# Altium schematic sheet EC20_TBOX.SchDoc(EC20_TBOX)
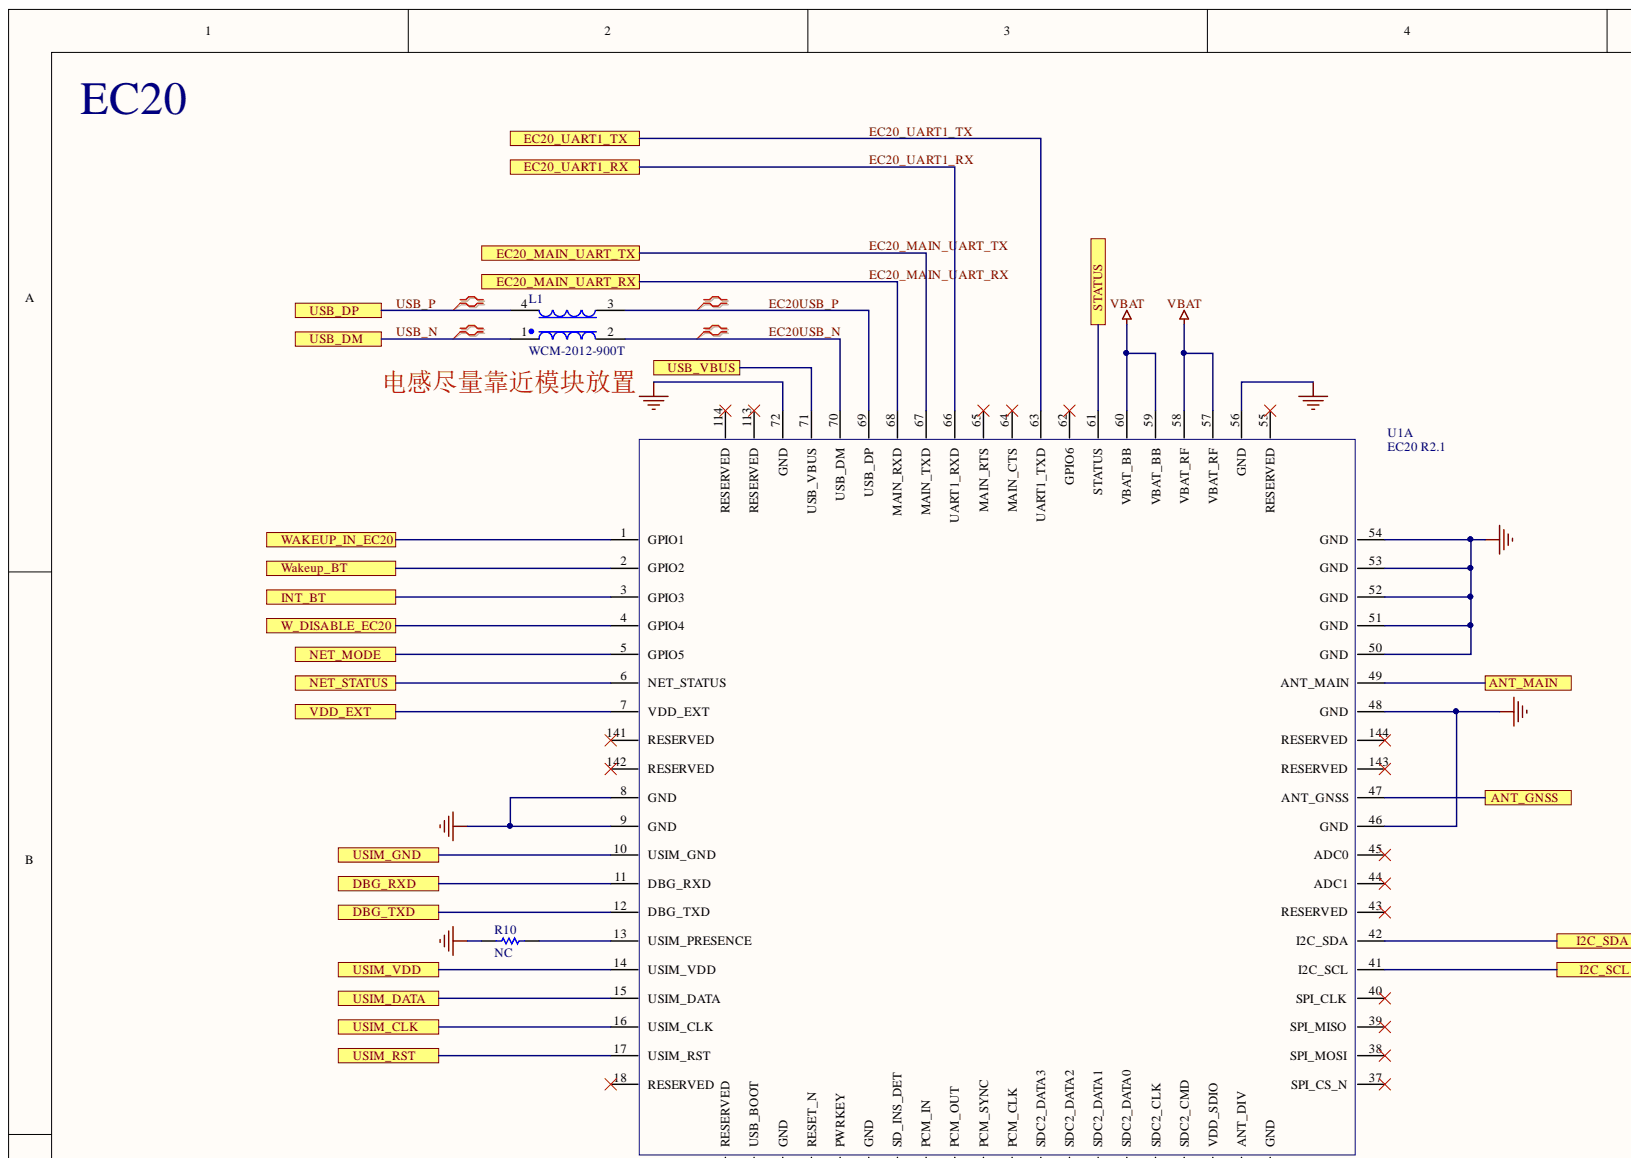
[diagram p0: EC20_TBOX.SchDoc, top left]
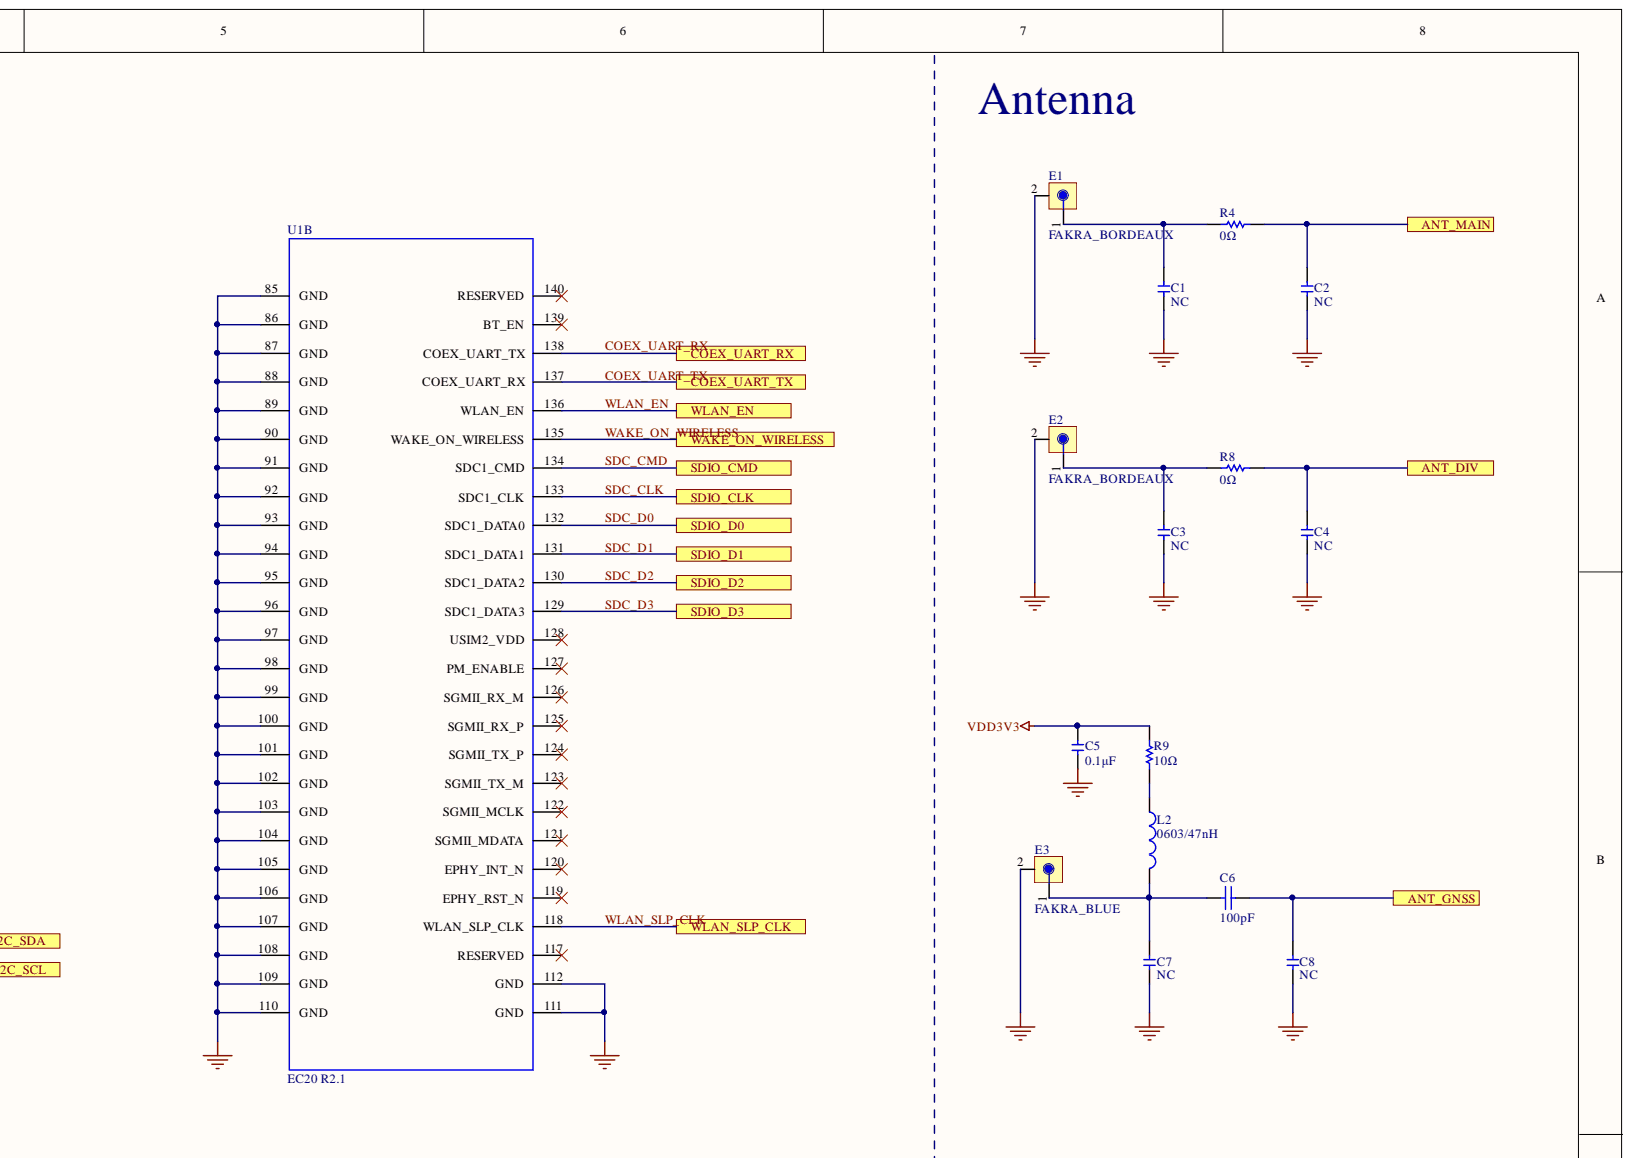
[diagram p1: EC20_TBOX.SchDoc, top right]
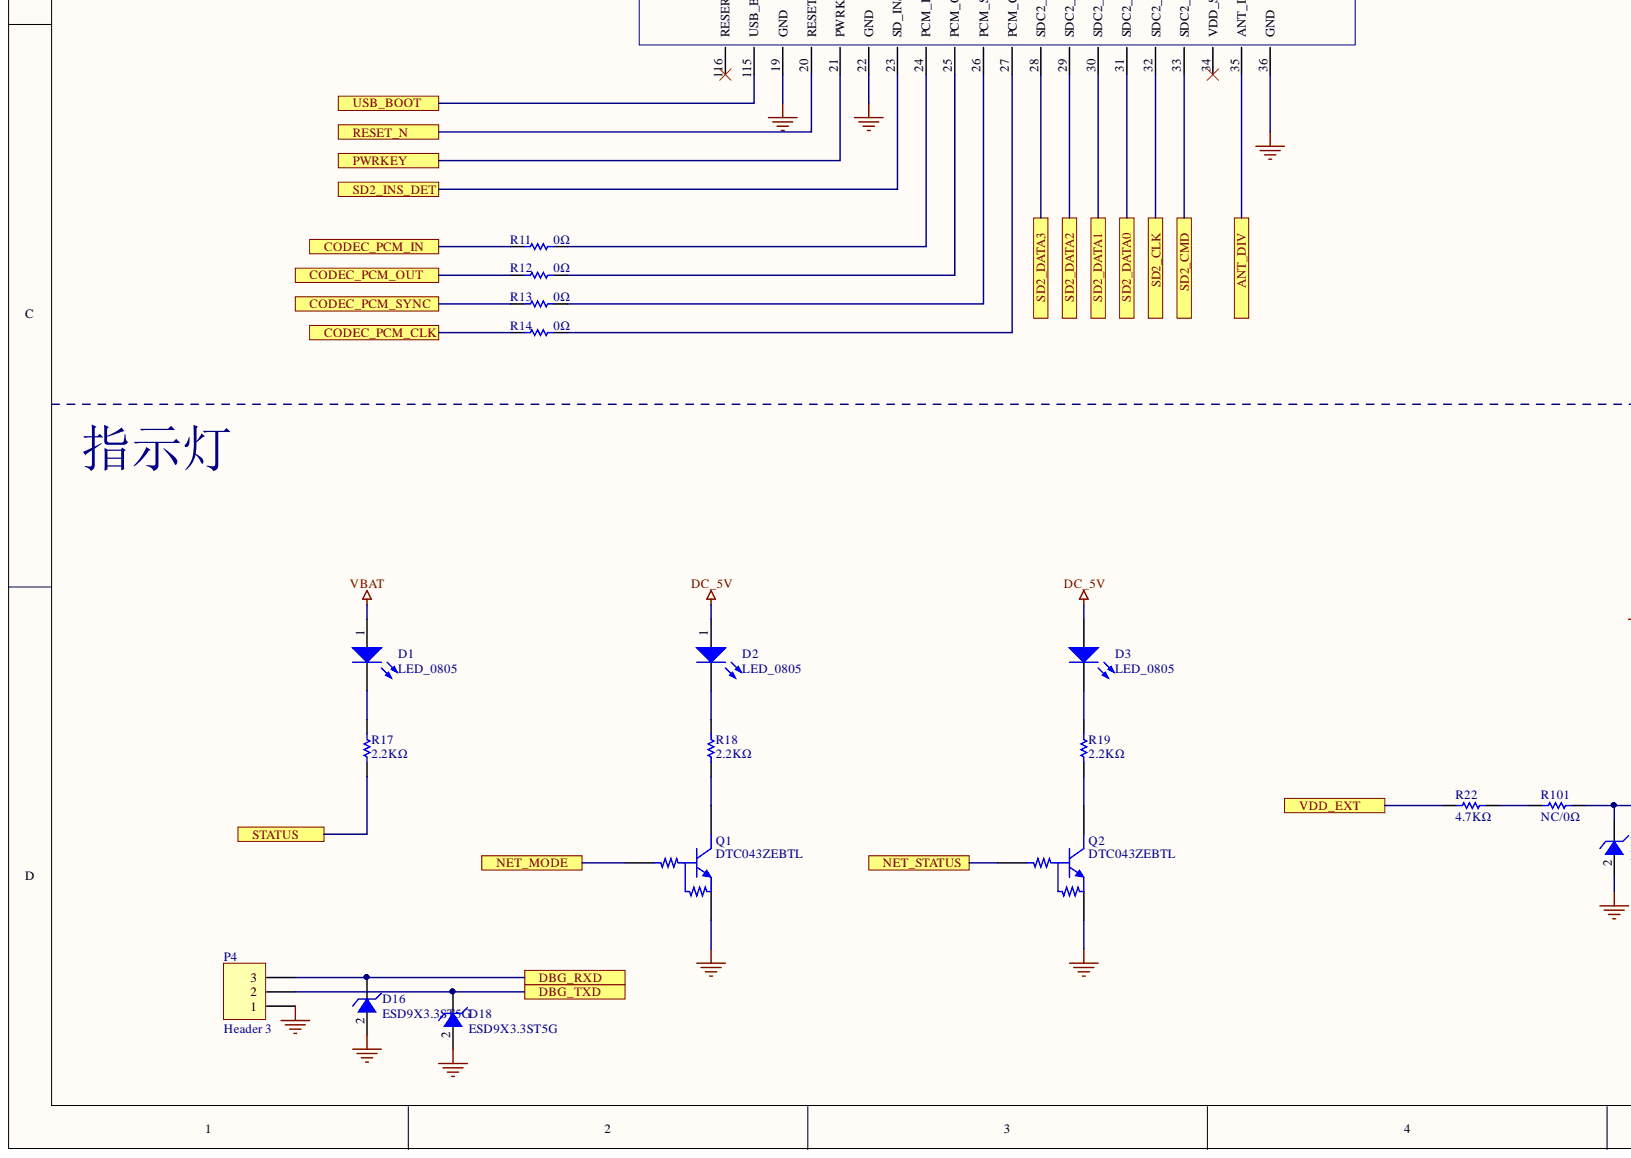
[diagram p2: EC20_TBOX.SchDoc, bottom left]
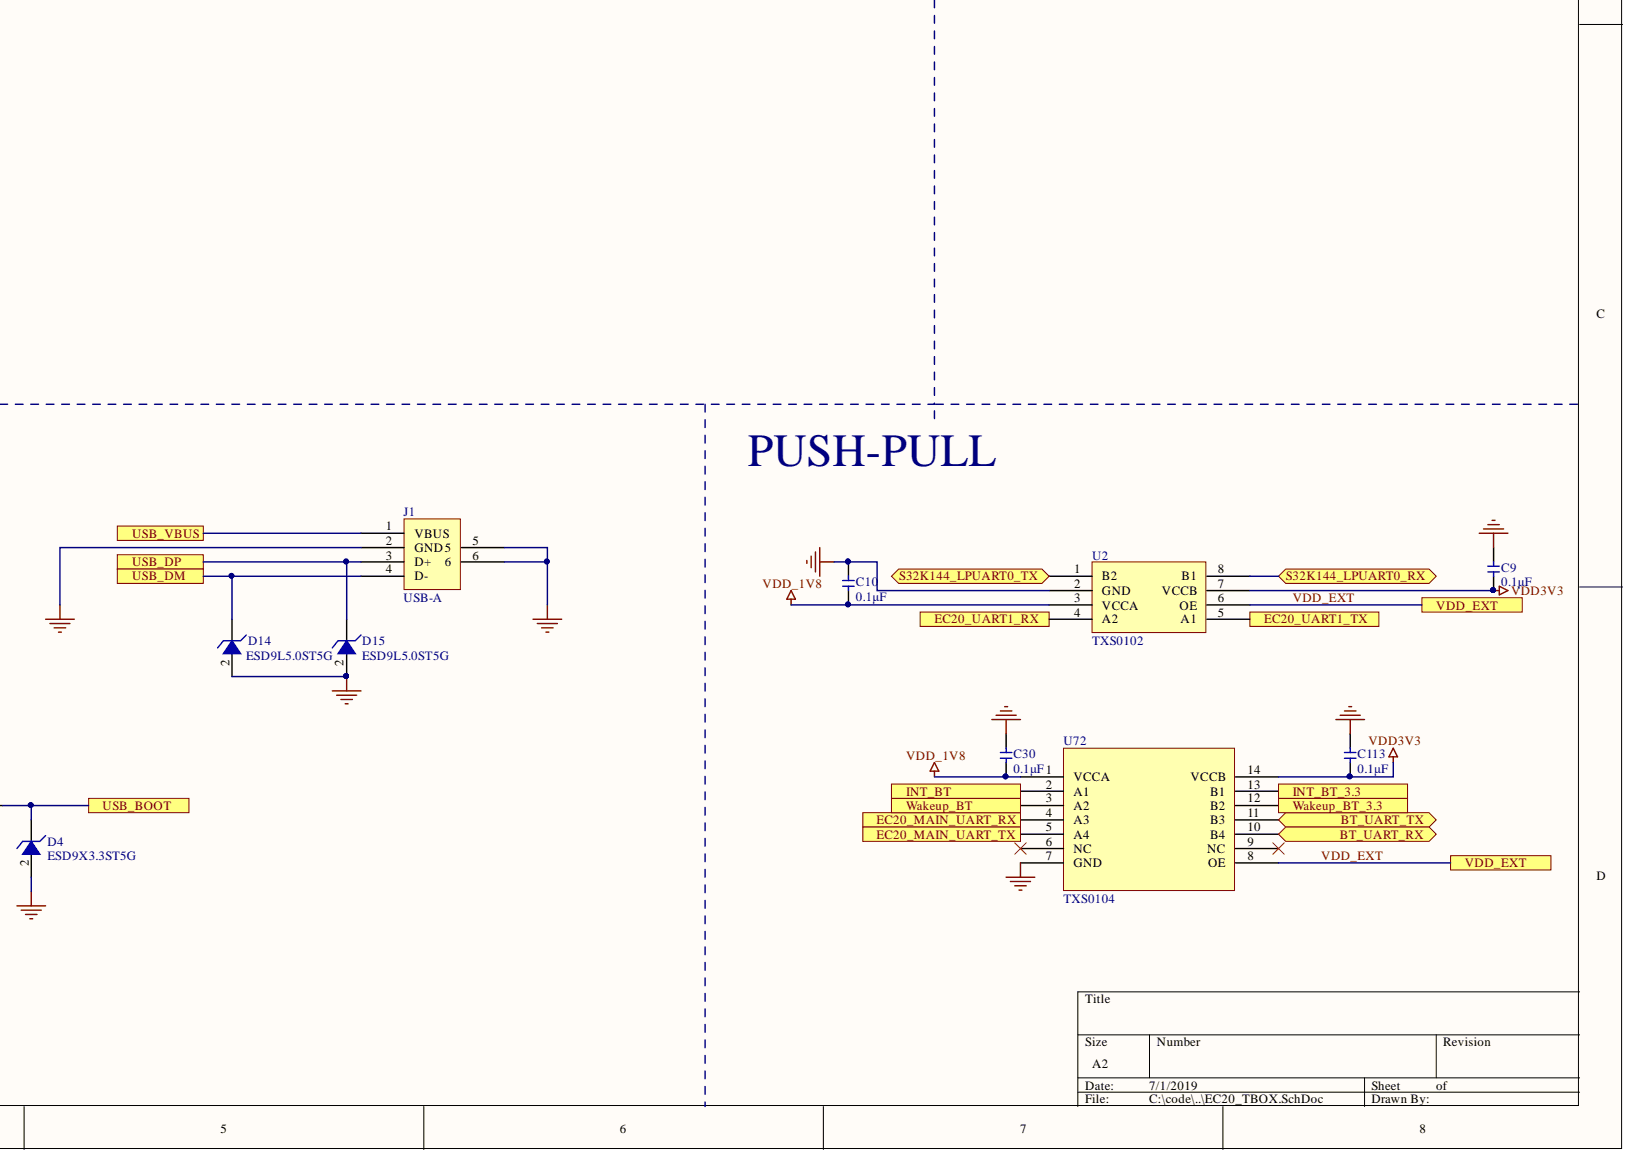
[diagram p3: EC20_TBOX.SchDoc, bottom right]

## Parts
C1  NC  [C0402]
C2  NC  [C0402]
C3  NC  [C0402]
C4  NC  [C0402]
C5  0.1μF  [C0402]
C6  100pF  [C0402]
C7  NC  [C0402]
C8  NC  [C0402]
C9  0.1μF  [C0402]
C10  0.1μF  [C0402]
C30  0.1μF  [C0402]
C113  0.1μF  [C0402]
D1  LED_0805  [LED_0805]
D2  LED_0805  [LED_0805]
D3  LED_0805  [LED_0805]
D4  ESD9X3.3ST5G  [SOD-923]
D14  ESD9L5.0ST5G  [SOD-923]
D15  ESD9L5.0ST5G  [SOD-923]
D16  ESD9X3.3ST5G  [SOD-923]
D18  ESD9X3.3ST5G  [SOD-923]
E1  FAKRA_BORDEAUX  [SMB-BORDEAUX_LTE]
E2  FAKRA_BORDEAUX  [SMB-BORDEAUX_LTE]
E3  FAKRA_BLUE  [SMB-BLUE_GPS]
J1  USB-A  [GT17HN-4DP-2H(A)(30)]
L1  WCM-2012-900T  [WCM-2012-900T]
L2  0603/47nH  [L0603]
P4  Header 3  [HDR3X1_A]
Q1  DTC043ZEBTL  [SOT723]
Q2  DTC043ZEBTL  [SOT723]
R4  0Ω  [R0402]
R8  0Ω  [R0402]
R9  10Ω  [R0402]
R10  NC  [R0402]
R11  0Ω  [R0402]
R12  0Ω  [R0402]
R13  0Ω  [R0402]
R14  0Ω  [R0402]
R17  2.2KΩ  [R0402]
R18  2.2KΩ  [R0402]
R19  2.2KΩ  [R0402]
R22  4.7KΩ  [R0402]
R101  NC/0Ω  [R0402]
U1  EC20 R2.1  [EC20_R2.1]  (parts A,B)
U2  TXS0102  [DCU-8]
U72  TXS0104  [TSSOP14]

## Ports
ANT_DIV, ANT_GNSS, ANT_MAIN, BT_UART_RX, BT_UART_TX, CODEC_PCM_CLK, CODEC_PCM_IN, CODEC_PCM_OUT, CODEC_PCM_SYNC, COEX_UART_RX, COEX_UART_TX, DBG_RXD, DBG_TXD, EC20_MAIN_UART_RX, EC20_MAIN_UART_TX, EC20_UART1_RX, EC20_UART1_TX, I2C_SCL, I2C_SDA, INT_BT, INT_BT_3.3, NET_MODE, NET_STATUS, PWRKEY, RESET_N, S32K144_LPUART0_RX, S32K144_LPUART0_TX, SD2_CLK, SD2_CMD, SD2_DATA0, SD2_DATA1, SD2_DATA2, SD2_DATA3, SD2_INS_DET, SDIO_CLK, SDIO_CMD, SDIO_D0, SDIO_D1, SDIO_D2, SDIO_D3, STATUS, USB_BOOT, USB_DM, USB_DP, USB_VBUS, USIM_CLK, USIM_DATA, USIM_GND, USIM_RST, USIM_VDD, VDD_EXT, W_DISABLE_EC20, WAKE_ON_WIRELESS, Wakeup_BT, Wakeup_BT_3.3, WAKEUP_IN_EC20, WLAN_EN, WLAN_SLP_CLK

## Nets
DC_5V: D2.1 D3.1
GND: C1.2 C2.2 C3.2 C4.2 C5.2 C7.2 C8.2 C9.2 C10.1 C30.1 C113.2 D4.2 D14.2 D15.2 D16.2 D18.2 E1.2 E2.2 E3.2 J1.2(GND) J1.5 J1.6 P4.1 Q1.2 Q2.2 R10.1 U1.8(GND) U1.9(GND) U1.19(GND) U1.22(GND) U1.36(GND) U1.46(GND) U1.48(GND) U1.50(GND) U1.51(GND) U1.52(GND) U1.53(GND) U1.54(GND) U1.56(GND) U1.72(GND) U1.85(GND) U1.86(GND) U1.87(GND) U1.88(GND) U1.89(GND) U1.90(GND) U1.91(GND) U1.92(GND) U1.93(GND) U1.94(GND) U1.95(GND) U1.96(GND) U1.97(GND) U1.98(GND) U1.99(GND) U1.100(GND) U1.101(GND) U1.102(GND) U1.103(GND) U1.104(GND) U1.105(GND) U1.106(GND) U1.107(GND) U1.108(GND) U1.109(GND) U1.110(GND) U1.111(GND) U1.112(GND) U2.2(GND) U72.7(GND)
VBAT: D1.1 U1.57(VBAT_RF) U1.58(VBAT_RF) U1.59(VBAT_BB) U1.60(VBAT_BB)
VDD3V3: C5.1 C9.1 C113.1 R9.1 U2.7(VCCB) U72.14(VCCB)
VDD_1V8: C10.2 C30.2 U2.3(VCCA) U72.1(VCCA)
ANT_DIV: C4.1 R8.1 U1.35(ANT_DIV) ; port ANT_DIV
ANT_GNSS: C6.1 C8.1 U1.47(ANT_GNSS) ; port ANT_GNSS
ANT_MAIN: C2.1 R4.1 U1.49(ANT_MAIN) ; port ANT_MAIN
BT_UART_RX: U72.10(B4) ; port BT_UART_RX
BT_UART_TX: U72.11(B3) ; port BT_UART_TX
CODEC_PCM_CLK: R14.1 ; port CODEC_PCM_CLK
CODEC_PCM_IN: R11.1 ; port CODEC_PCM_IN
CODEC_PCM_OUT: R12.1 ; port CODEC_PCM_OUT
CODEC_PCM_SYNC: R13.1 ; port CODEC_PCM_SYNC
COEX_UART_RX: U1.138(COEX_UART_TX) ; port COEX_UART_RX
COEX_UART_TX: U1.137(COEX_UART_RX) ; port COEX_UART_TX
DBG_RXD: D16.1 P4.3 U1.11(DBG_RXD) ; port DBG_RXD
DBG_TXD: D18.1 P4.2 U1.12(DBG_TXD) ; port DBG_TXD
EC20_MAIN_UART_RX: U1.68(MAIN_RXD) U72.4(A3) ; port EC20_MAIN_UART_RX
EC20_MAIN_UART_TX: U1.67(MAIN_TXD) U72.5(A4) ; port EC20_MAIN_UART_TX
EC20_UART1_RX: U1.66(UART1_RXD) U2.4(A2) ; port EC20_UART1_RX
EC20_UART1_TX: U1.63(UART1_TXD) U2.5(A1) ; port EC20_UART1_TX
EC20USB_N: L1.2 U1.70(USB_DM)
EC20USB_P: L1.3 U1.69(USB_DP)
I2C_SCL: U1.41(I2C_SCL) ; port I2C_SCL
I2C_SDA: U1.42(I2C_SDA) ; port I2C_SDA
INT_BT: U1.3(GPIO3) U72.2(A1) ; port INT_BT
INT_BT_3.3: U72.13(B1) ; port INT_BT_3.3
NET_MODE: Q1.1 U1.5(GPIO5) ; port NET_MODE
NET_STATUS: Q2.1 U1.6(NET_STATUS) ; port NET_STATUS
PWRKEY: U1.21(PWRKEY) ; port PWRKEY
RESET_N: U1.20(RESET_N) ; port RESET_N
S32K144_LPUART0_RX: U2.8(B1) ; port S32K144_LPUART0_RX
S32K144_LPUART0_TX: U2.1(B2) ; port S32K144_LPUART0_TX
SD2_CLK: U1.32(SDC2_CLK) ; port SD2_CLK
SD2_CMD: U1.33(SDC2_CMD) ; port SD2_CMD
SD2_DATA0: U1.31(SDC2_DATA0) ; port SD2_DATA0
SD2_DATA1: U1.30(SDC2_DATA1) ; port SD2_DATA1
SD2_DATA2: U1.29(SDC2_DATA2) ; port SD2_DATA2
SD2_DATA3: U1.28(SDC2_DATA3) ; port SD2_DATA3
SD2_INS_DET: U1.23(SD_INS_DET) ; port SD2_INS_DET
SDIO_CLK: U1.133(SDC1_CLK) ; port SDIO_CLK
SDIO_CMD: U1.134(SDC1_CMD) ; port SDIO_CMD
SDIO_D0: U1.132(SDC1_DATA0) ; port SDIO_D0
SDIO_D1: U1.131(SDC1_DATA1) ; port SDIO_D1
SDIO_D2: U1.130(SDC1_DATA2) ; port SDIO_D2
SDIO_D3: U1.129(SDC1_DATA3) ; port SDIO_D3
STATUS: R17.2 U1.61(STATUS) ; port STATUS
USB_BOOT: D4.1 R101.1 U1.115(USB_BOOT) ; port USB_BOOT
USB_N: D14.1 J1.4(D-) L1.1 ; port USB_DM
USB_P: D15.1 J1.3(D+) L1.4 ; port USB_DP
USB_VBUS: J1.1(VBUS) U1.71(USB_VBUS) ; port USB_VBUS
USIM_CLK: U1.16(USIM_CLK) ; port USIM_CLK
USIM_DATA: U1.15(USIM_DATA) ; port USIM_DATA
USIM_GND: U1.10(USIM_GND) ; port USIM_GND
USIM_RST: U1.17(USIM_RST) ; port USIM_RST
USIM_VDD: U1.14(USIM_VDD) ; port USIM_VDD
VDD_EXT: R22.2 U1.7(VDD_EXT) U2.6(OE) U72.8(OE) ; port VDD_EXT
W_DISABLE_EC20: U1.4(GPIO4) ; port W_DISABLE_EC20
WAKE_ON_WIRELESS: U1.135(WAKE_ON_WIRELESS) ; port WAKE_ON_WIRELESS
Wakeup_BT: U1.2(GPIO2) U72.3(A2) ; port Wakeup_BT
Wakeup_BT_3.3: U72.12(B2) ; port Wakeup_BT_3.3
WAKEUP_IN_EC20: U1.1(GPIO1) ; port WAKEUP_IN_EC20
WLAN_EN: U1.136(WLAN_EN) ; port WLAN_EN
WLAN_SLP_CLK: U1.118(WLAN_SLP_CLK) ; port WLAN_SLP_CLK
NetC1_1: C1.1 E1.1 R4.2
NetC3_1: C3.1 E2.1 R8.2
NetC6_2: C6.2 C7.1 E3.1 L2.2
NetD1_2: D1.2 R17.1
NetD2_2: D2.2 R18.1
NetD3_2: D3.2 R19.1
NetL2_1: L2.1 R9.2
NetQ1_3: Q1.3 R18.2
NetQ2_3: Q2.3 R19.2
NetR10_2: R10.2 U1.13(USIM_PRESENCE)
NetR11_2: R11.2 U1.24(PCM_IN)
NetR12_2: R12.2 U1.25(PCM_OUT)
NetR13_2: R13.2 U1.26(PCM_SYNC)
NetR14_2: R14.2 U1.27(PCM_CLK)
NetR22_1: R22.1 R101.2

Not connected: U1 18(RESERVED) 34(VDD_SDIO) 37(SPI_CS_N) 38(SPI_MOSI) 39(SPI_MISO) 40(SPI_CLK) 43(RESERVED) 44(ADC1) 45(ADC0) 55(RESERVED) 62(GPIO6) 64(MAIN_CTS) 65(MAIN_RTS) 113(RESERVED) 114(RESERVED) 116(RESERVED) 117(RESERVED) 119(EPHY_RST_N) 120(EPHY_INT_N) 121(SGMII_MDATA) 122(SGMII_MCLK) 123(SGMII_TX_M) 124(SGMII_TX_P) 125(SGMII_RX_P) 126(SGMII_RX_M) 127(PM_ENABLE) 128(USIM2_VDD) 139(BT_EN) 140(RESERVED) 141(RESERVED) 142(RESERVED) 143(RESERVED) 144(RESERVED); U72 6(NC) 9(NC)

## Library data of the parts
C1-C5 C7-C10 C30 C113 = Cap Semi: Capacitor (Semiconductor SIM Model); Simulation: CAP; Signal Integrity: Cap Semi; Published: 8-Jun-2000; PackageReference: C1206; PackageDescription: Chip Capacitor; Library Name: Miscellaneous Devices.SchLib; LatestRevisionNote: IPC-7351 Footprint Added.; LatestRevisionDate: 29-May-2009; Component Kind: Standard; Publisher: Altium Limited
  C1-C4 C7 C8: Value: NC
  C5 C9 C10 C30 C113: Value: 0.1μF
C6 = Cap: Capacitor; Value: 100pF; Simulation: CAP; Signal Integrity: Cap; Published: 8-Jun-2000; PackageReference: RAD-0.3; PackageDescription: Radial Cap, Thru-Hole; 2 Leads; 0.3 in Pin Spacing; Library Name: Miscellaneous Devices.SchLib; LatestRevisionNote: Re-released for DXP Platform.; LatestRevisionDate: 17-Jul-2002; Component Kind: Standard; Publisher: Altium Limited
D1-D3 = LED0: Typical INFRARED GaAs LED; Simulation: LED0; Published: 8-Jun-2000; PackageReference: LED-0; PackageDescription: LED; 2 Leads; Library Name: Miscellaneous Devices.SchLib; LatestRevisionNote: Mech Layer 1 removed.; LatestRevisionDate: 08-Jul-2005; Component Kind: Standard; Publisher: Altium Limited
D4 D14-D16 D18 = D Zener: Zener Diode; Simulation: ZENER; Signal Integrity: D Zener; Published: 8-Jun-2000; PackageReference: DIODE-0.7; PackageDescription: Diode, Thru-Hole; 2 Leads; 0.7 in Pin Spacing; Library Name: Miscellaneous Devices.SchLib; LatestRevisionNote: Re-released for DXP Platform.; LatestRevisionDate: 17-Jul-2002; Component Kind: Standard; Publisher: Altium Limited
E1-E3 = ANT_CN: Library Name: Miscellaneous Devices.SchLib; Component Kind: Standard
L1 = Inductor Iron Dot11: Magnetic-Core Inductor with Winding Polarity Marking; Value: 10mH; Signal Integrity: Inductor Iron Dot; Published: 24-Mar-1999; PackageReference: DIODE_SMC; PackageDescription: Diode; 2 Leads; Library Name: Miscellaneous Devices.SchLib; LatestRevisionNote: Re-released for DXP Platform.; LatestRevisionDate: 17-Jul-2002; Component Kind: Standard; Publisher: Altium Limited
L2 = Inductor: Code_IEC: 1005; Value: 0603/47nH; Simulation: INDUCTOR; Signal Integrity: Inductor; Published: 8-Jun-2000; PackageReference: 0402-A; PackageDescription: Chip Inductor; Library Name: Miscellaneous Devices.SchLib; LatestRevisionNote: IPC-7351 Footprint Added.; LatestRevisionDate: 29-May-2009; Description: Inductor; Component Kind: Standard; Code_JEITA: 0402; Publisher: Altium Limited
P4 = Header 3: Header, 3-Pin; Signal Integrity: Connector; Library Name: Miscellaneous Connectors.IntLib; LatestRevisionNote: Re-released for DXP Platform.; LatestRevisionDate: 17-Jul-2002; Component Kind: Standard; Publisher: Altium Limited
Q1 Q2 = DTC043ZEBTL: NPN Darlington Bipolar Transistor; Simulation: NPN3; Signal Integrity: NPN; Published: 8-Jun-2000; PackageReference: TO-237-AA; PackageDescription: TO, Flat Index; 3 In-Line, Axial Leads; Body Dia. 4.8mm; Lead Dia. 0.56 mm (max); Library Name: Miscellaneous Devices.SchLib; LatestRevisionNote: Re-released for DXP Platform.; LatestRevisionDate: 17-Jul-2002; Component Kind: Standard; Code_JEDEC: TO-237-AA; Publisher: Altium Limited
R4 R8-R14 R17-R19 R22 R101 = Res1: Resistor; Simulation: RESISTOR; Signal Integrity: Res; Published: 8-Jun-2000; PackageReference: AXIAL-0.3; PackageDescription: Axial Device, Thru-Hole; 2 Leads; 0.3 in Pin Spacing; Library Name: Miscellaneous Devices.SchLib; LatestRevisionNote: Re-released for DXP Platform.; LatestRevisionDate: 17-Jul-2002; Component Kind: Standard; Publisher: Altium Limited
  R4 R8 R11-R14: Value: 0Ω
  R9: Value: 10Ω
  R10: Value: NC
  R17-R19: Value: 2.2KΩ
  R22: Value: 4.7KΩ
  R101: Value: NC/0Ω
J1 = USB-A: Library Name: Miscellaneous Devices.SchLib; Component Kind: Standard
U1 = EC20 R2.1: Library Name: Miscellaneous Devices.SchLib; Component Kind: Standard
U2 = TXS0102: Library Name: EC20_Project.SCHLIB; Component Kind: Standard
U72 = TXS0104: Library Name: EC20_Project.SCHLIB; Component Kind: Standard
